# Altium schematic sheet 扩展板GPS-L80R.SchDoc
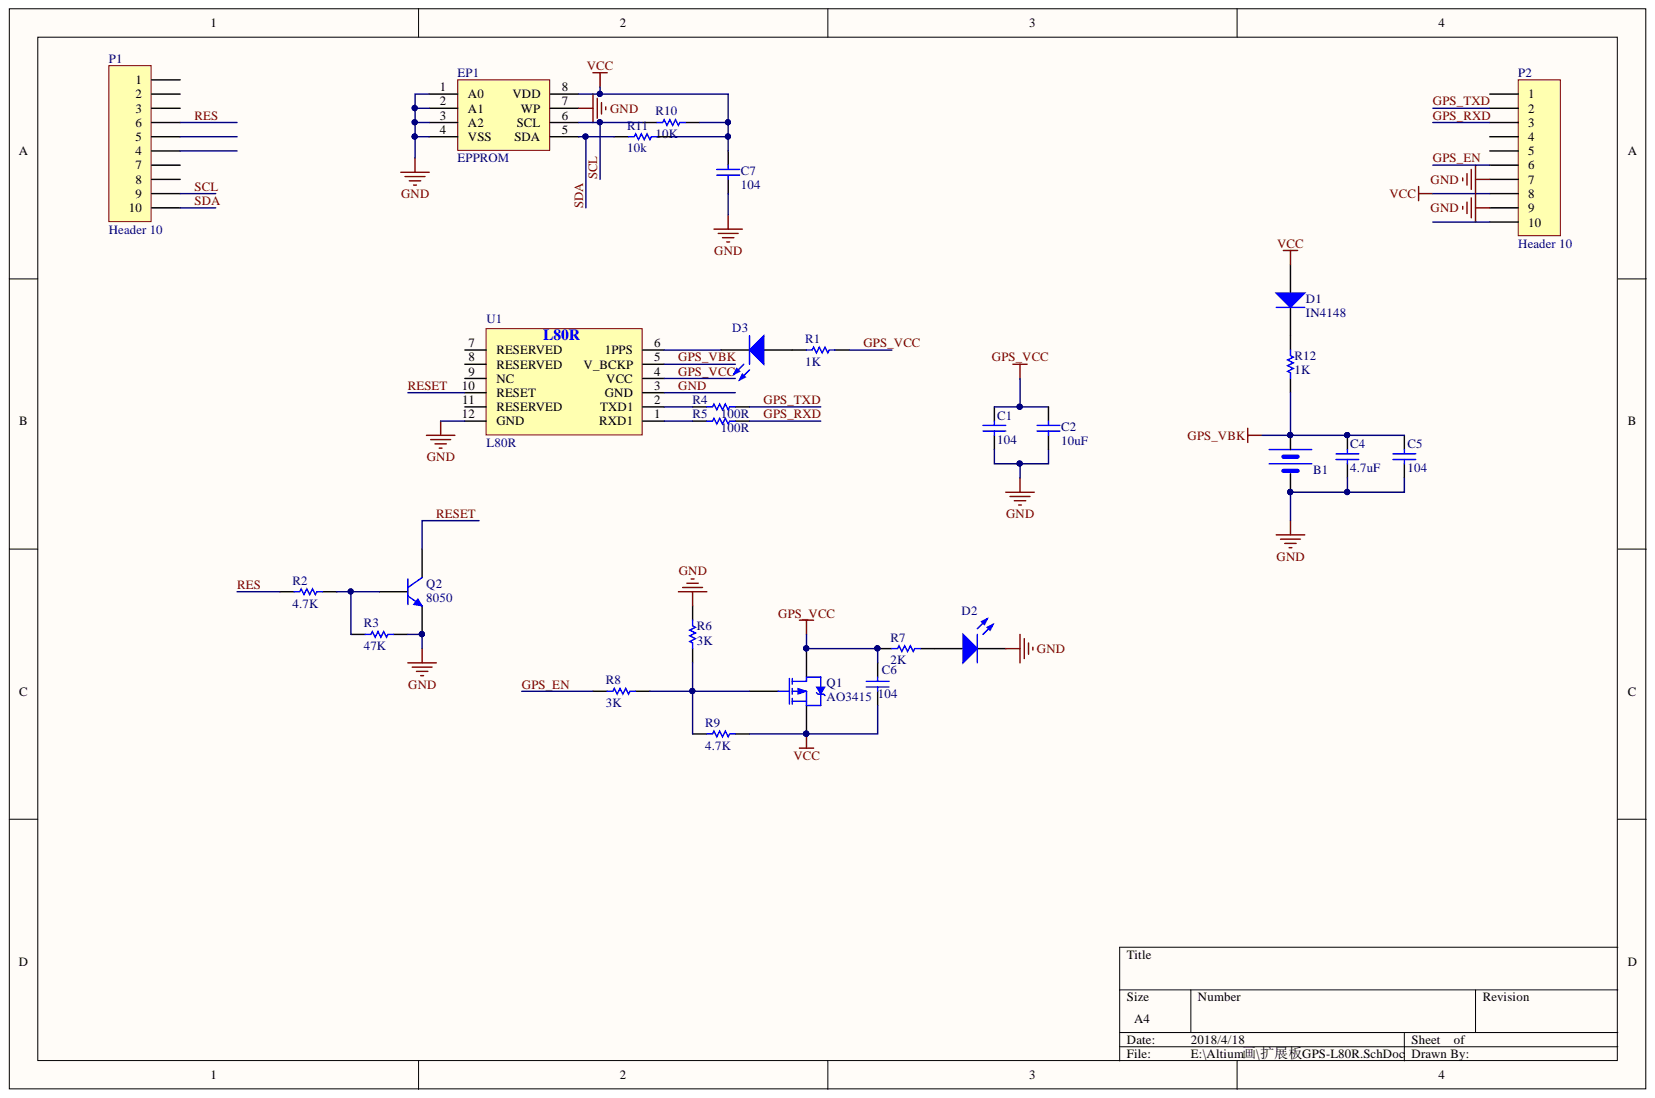
[diagram p0: 扩展板GPS-L80R.SchDoc]

## Parts
B1  XH414  [XH414]  (value not drawn)
C1  value 104  [C0603]  (hidden comment: CAP)
C2  10uF  [C0805]
C4  value 4.7uF  [C0603]  (hidden comment: CAP)
C5  value 104  [C0603]  (hidden comment: CAP)
C6  value 104  [C0603]  (hidden comment: CAP)
C7  104  [C0603]
D1  IN4148  [DIODE_1]
D2  LED  [LED_0805]  (value not drawn)
D3  LED  [LED_0805]  (value not drawn)
EP1  EPPROM  [SO-8]
P1  Header 10  [HDR1X10]
P2  Header 10  [HDR1X10]
Q1  AO3415  [SOT23_N]
Q2  8050  [SOT23_N - 123]
R1  1K  [R0603]
R2  4.7K  [R0603]
R3  47K  [R0603]
R4  100R  [R0603]
R5  100R  [R0603]
R6  3K  [R0603]
R7  2K  [R0603]
R8  3K  [R0603]
R9  4.7K  [R0603]
R10  10K  [R0603]
R11  10k  [R0603]
R12  1K  [R0603]
U1  L80R  [L80R]

## Nets
VCC: C6.1 C7.2 D1.1 EP1.8(VDD) P2.8 Q1.3 R9.2 R10.1 R11.1
GND: B1.2 C1.1 C2.1 C4.1 C5.1 C7.1 D2.2 EP1.1(A0) EP1.2(A1) EP1.3(A2) EP1.4(VSS) EP1.7(WP) P2.7 P2.9 Q2.2 R3.1 R6.2 U1.3(GND) U1.12(GND)
GPS_EN: P2.6 R8.2
GPS_RXD: P2.3 R5.2
GPS_TXD: P2.2 R4.2
GPS_VBK: B1.1 C4.2 C5.2 R12.1 U1.5(V_BCKP)
GPS_VCC: C1.2 C2.2 C6.2 Q1.2 R1.2 R7.1 U1.4(VCC)
RES: P1.6 R2.1
RESET: Q2.3 U1.10(RESET)
SCL: EP1.6(SCL) P1.9 R10.2
SDA: EP1.5(SDA) P1.10 R11.2
NetD1_2: D1.2 R12.2
NetD2_1: D2.1 R7.2
NetD3_1: D3.1 R1.1
NetD3_2: D3.2 U1.6(1PPS)
NetQ1_1: Q1.1 R6.1 R8.1 R9.1
NetQ2_1: Q2.1 R2.2 R3.2
NetR4_1: R4.1 U1.2(TXD1)
NetR5_1: R5.1 U1.1(RXD1)

Not connected: P1 1 2 3 4 5 7 8; P2 1 4 5 10; U1 7(RESERVED) 8(RESERVED) 9(NC) 11(RESERVED)

## Library data of the parts
B1 = XH414: Part Value: XH414H IV01E; Component Kind: Standard; Library Name: NB-Lib.SchLib
C1 C4-C6 = CAP: CAP-0603; Component Kind: Standard; Library Name: Commodity_tracker_LIB.IntLib
C2 C7 = CAP: Capacitor; Component Kind: Standard; Library Name: Devices.IntLib
D1 = DIODE: Component Kind: Standard; Description: DIODE; Library Name: Devices.IntLib
D2 D3 = LED1: Typical RED GaAs LED; Component Kind: Standard; LatestRevisionDate: 08-Jul-2005; LatestRevisionNote: Mech Layer 1 removed.; Library Name: Devices.IntLib; PackageDescription: LED; 2 Leads; PackageReference: LED-1; Published: 8-Jun-2000; Simulation: LED1; Publisher: Altium Limited
EP1 = EPPROM: ROM: 24C02; Component Kind: Standard; Library Name: IC.IntLib
P1 P2 = Header 10: Header, 10-Pin; Component Kind: Standard; LatestRevisionDate: 17-Jul-2002; LatestRevisionNote: Re-released for DXP Platform.; Library Name: Connectors.IntLib; Signal Integrity: Connector; Publisher: Altium Limited
Q1 = PMOS-2: P-Channel Power MOSFET; Component Kind: Standard; Library Name: Devices.IntLib; Simulation: IRF9510
Q2 = NPN: NPN Bipolar Transistor; Code_JEDEC: TO-226-AA; Component Kind: Standard; LatestRevisionDate: 17-Jul-2002; LatestRevisionNote: Re-released for DXP Platform.; Library Name: Devices.IntLib; PackageDescription: TO, Flat Index; 3 In-Line, Axial Leads; Body Dia. 4.8mm; Leads 0.55 x 0.51 mm (max); PackageReference: TO-226-AA; Published: 8-Jun-2000; Signal Integrity: NPN; Simulation: NPN; Publisher: Altium Limited
R1 R12 = Res1: Resistor; Component Kind: Standard; LatestRevisionDate: 17-Jul-2002; LatestRevisionNote: Re-released for DXP Platform.; Library Name: Devices.IntLib; PackageDescription: Axial Device, Thru-Hole; 2 Leads; 0.3 in Pin Spacing; PackageReference: AXIAL-0.3; Published: 8-Jun-2000; Signal Integrity: Res; Simulation: RESISTOR; Value: 1K; Publisher: Altium Limited
R2-R11 = Res1: Resistor; Value: 1K; Component Kind: Standard; LatestRevisionDate: 17-Jul-2002; LatestRevisionNote: Re-released for DXP Platform.; Library Name: Devices.IntLib; PackageDescription: Axial Device, Thru-Hole; 2 Leads; 0.3 in Pin Spacing; PackageReference: AXIAL-0.3; Published: 8-Jun-2000; Signal Integrity: Res; Simulation: RESISTOR; Publisher: Altium Limited
U1 = L80R: Quectel-L80R; Component Kind: Standard; Library Name: Commodity_tracker_LIB.IntLib
